# Altium schematic sheet [03]-CONNECTOR,SBC.SchDoc (continued)

## Library data of the parts
C1 = C0402S471K3RACAUTO: Cap Ceramic 470pF 25V X7R 10% SMD 0402 125°C Paper T/R; Manufacturer: KEMET; Component Kind: Standard; ComponentLink1Description: http://datasheet.octopart.com/C0402S471K3RACAUTO-KEMET-datasheet-84169754.pdf; ComponentLink1URL: http://datasheet.octopart.com/C0402S471K3RACAUTO-KEMET-datasheet-84169754.pdf; Manufacturer Part Number: C0402S471K3RACAUTO; Pricing 1: 1 = 0.53000, 10 = 0.37200, 100 = 0.24480, 500 = 0.18132, 1000 = 0.15412, 2500 = 0.14506, 5000 = 0.13599 (USD); Stock 1: 10000; Supplier 1: Digikey; Supplier Part Number 1: 399-19394-1-ND
C2 C3 C12 C23 = GRT21BR61E226ME13L: 0805 22 uF 25 V ±20% Tolerance X5R Multilayer Ceramic Capacitor; Manufacturer: Murata; Voltage Rating: 25V; Case Code (Imperial): 0805; Case Code (Metric): 2012; Component Kind: Standard; ComponentLink1Description: http://datasheet.octopart.com/GRT21BR61E226ME13L-Murata-datasheet-82944879.pdf?src-supplier=Future+Electronics; ComponentLink1URL: http://datasheet.octopart.com/GRT21BR61E226ME13L-Murata-datasheet-82944879.pdf?src-supplier=Future+Electronics; Length: 0.079inch; Manufacturer Part Number: GRT21BR61E226ME13L; Max Operating Temperature: 85°C; Min Operating Temperature: -55°C; Mount: Surface Mount; Packaging: Tape and Reel; Pricing 1: 1 = 0.73000, 10 = 0.51300, 100 = 0.33770, 500 = 0.25018, 1000 = 0.21265 (USD); Ratings: AEC-Q200; Stock 1: 494235; Supplier 1: Digi-Key; Supplier Part Number 1: 490-12389-1-ND; Thickness: 0.057inch; Tolerance: 20%; Width: 0.049inch
C4 = EMZA350ADA470MF61G: Aluminum Electrolytic Capacitor 47UF 35V 20%, SMD, Full Reel; Manufacturer: United Chemi-Con; Dielectric Material: Aluminium; ESR (Equivalent Series Resistance): 360mR; Lead Diameter: 0.8mm; Leakage Current: 3uA; Life (Hours): 2000Hour; Ripple Current: 240mA; Case/Package: Radial; Component Kind: Standard; ComponentLink1Description: http://www.farnell.com/datasheets/1470278.pdf?src-supplier=Element14; ComponentLink1URL: http://www.farnell.com/datasheets/1470278.pdf?src-supplier=Element14; ComponentLink2Description: http://datasheet.octopart.com/EMZA350ADA470MF61G-United-Chemi-Con-datasheet-10742166.pdf?src-supplier=Element14; ComponentLink2URL: http://datasheet.octopart.com/EMZA350ADA470MF61G-United-Chemi-Con-datasheet-10742166.pdf?src-supplier=Element14; ComponentLink3Description: http://datasheet.octopart.com/EMZA350ADA470MF61G-United-Chemi-Con-datasheet-23700410.pdf?src-supplier=Newark; ComponentLink3URL: http://datasheet.octopart.com/EMZA350ADA470MF61G-United-Chemi-Con-datasheet-23700410.pdf?src-supplier=Newark; ComponentLink4Description: http://datasheet.octopart.com/EMZA350ADA470MF61G-United-Chemi-Con-datasheet-14460521.pdf?src-supplier=Electro+Sonic; ComponentLink4URL: http://datasheet.octopart.com/EMZA350ADA470MF61G-United-Chemi-Con-datasheet-14460521.pdf?src-supplier=Electro+Sonic; ComponentLink5Description: http://datasheet.octopart.com/EMZA350ADA470MF61G-United-Chemi-Con-datasheet-15930.pdf?src-supplier=Digi-Key; ComponentLink5URL: http://datasheet.octopart.com/EMZA350ADA470MF61G-United-Chemi-Con-datasheet-15930.pdf?src-supplier=Digi-Key; ComponentLink6Description: http://datasheet.octopart.com/EMZA350ADA470MF61G-United-Chemi-Con-datasheet-8459204.pdf?src-supplier=Element14; ComponentLink6URL: http://datasheet.octopart.com/EMZA350ADA470MF61G-United-Chemi-Con-datasheet-8459204.pdf?src-supplier=Element14; ComponentLink7Description: http://datasheet.octopart.com/EMZA350ADA470MF61G-United-Chemi-Con-datasheet-8814951.pdf?src-supplier=Element14; ComponentLink7URL: http://datasheet.octopart.com/EMZA350ADA470MF61G-United-Chemi-Con-datasheet-8814951.pdf?src-supplier=Element14; ComponentLink8Description: http://datasheet.octopart.com/EMZA350ADA470MF61G-United-Chemi-Con-datasheet-21267361.pdf?src-supplier=Newark; ComponentLink8URL: http://datasheet.octopart.com/EMZA350ADA470MF61G-United-Chemi-Con-datasheet-21267361.pdf?src-supplier=Newark; ComponentLink9Description: http://datasheet.octopart.com/EMZA350ADA470MF61G-United-Chemi-Con-datasheet-33025258.pdf?src-supplier=Electro+Sonic; ComponentLink9URL: http://datasheet.octopart.com/EMZA350ADA470MF61G-United-Chemi-Con-datasheet-33025258.pdf?src-supplier=Electro+Sonic; ComponentLink10Description: http://datasheet.octopart.com/EMZA350ADA470MF61G-United-Chemi-Con-datasheet-43457141.pdf?src-supplier=Future+Electronics; ComponentLink10URL: http://datasheet.octopart.com/EMZA350ADA470MF61G-United-Chemi-Con-datasheet-43457141.pdf?src-supplier=Future+Electronics; ComponentLink11Description: http://datasheet.octopart.com/EMZA350ADA470MF61G-United-Chemi-Con-datasheet-62043274.pdf?src-supplier=Electro+Sonic; ComponentLink11URL: http://datasheet.octopart.com/EMZA350ADA470MF61G-United-Chemi-Con-datasheet-62043274.pdf?src-supplier=Electro+Sonic; Diameter: 6.3mm; Height: 5.8mm; Height - Seated (Max): 0.228inch; Impedance: 360mR; Lead Free: Lead Free; Lead Pitch: 1.9mm; Length: 5.8mm; Manufacturer Part Number: EMZA350ADA470MF61G; Max Operating Temperature: 105°C; Min Operating Temperature: -55°C; Mount: Surface Mount; Number of Pins: 61; Package Quantity: 1; Packaging: Tape and Reel; Pricing 1: 1 = 0.70000, 10 = 0.49500, 100 = 0.32600, 500 = 0.24150 (USD); REACH SVHC: Unknown; Ripple Current (AC): 240mA; Stock 1: 43543; Supplier 1: Digi-Key; Supplier Part Number 1: 565-2558-1-ND; Termination: SMD/SMT; Tolerance: 20%; Voltage Rating: 35V; Voltage Rating (DC): 35V
C5 = CGA6P3X7S1H106K250AE: Cap Ceramic 10uF 50V X7S 10% Pad SMD 1210 Soft Termination 125C Automotive T/R; Manufacturer: TDK; Case Code (Imperial): 1210; Case Code (Metric): 3225; Component Kind: Standard; ComponentLink1Description: http://www.mouser.com/ds/2/400/lcc_commercial_soft_en-775842.pdf?src-supplier=Mouser; ComponentLink1URL: http://www.mouser.com/ds/2/400/lcc_commercial_soft_en-775842.pdf?src-supplier=Mouser; ComponentLink2Description: http://www.farnell.com/datasheets/1863333.pdf?src-supplier=Element14; ComponentLink2URL: http://www.farnell.com/datasheets/1863333.pdf?src-supplier=Element14; ComponentLink3Description: http://datasheet.octopart.com/CGA6P3X7S1H106K250AE-TDK-datasheet-26765558.pdf?src-supplier=Newark; ComponentLink3URL: http://datasheet.octopart.com/CGA6P3X7S1H106K250AE-TDK-datasheet-26765558.pdf?src-supplier=Newark; ComponentLink4Description: http://datasheet.octopart.com/CGA6P3X7S1H106K250AE-TDK-datasheet-33037363.pdf?src-supplier=Newark; ComponentLink4URL: http://datasheet.octopart.com/CGA6P3X7S1H106K250AE-TDK-datasheet-33037363.pdf?src-supplier=Newark; ComponentLink5Description: http://datasheet.octopart.com/CGA6P3X7S1H106K250AE-TDK-datasheet-82475251.pdf?src-supplier=Newark; ComponentLink5URL: http://datasheet.octopart.com/CGA6P3X7S1H106K250AE-TDK-datasheet-82475251.pdf?src-supplier=Newark; ComponentLink6Description: http://datasheet.octopart.com/CGA6P3X7S1H106K250AE-TDK-datasheet-57863114.pdf?src-supplier=Newark; ComponentLink6URL: http://datasheet.octopart.com/CGA6P3X7S1H106K250AE-TDK-datasheet-57863114.pdf?src-supplier=Newark; ComponentLink7Description: http://datasheet.octopart.com/CGA6P3X7S1H106K250AE-TDK-datasheet-41194876.pdf?src-supplier=Future+Electronics; ComponentLink7URL: http://datasheet.octopart.com/CGA6P3X7S1H106K250AE-TDK-datasheet-41194876.pdf?src-supplier=Future+Electronics; ComponentLink8Description: http://datasheet.octopart.com/CGA6P3X7S1H106K250AE.-TDK-datasheet-33009218.pdf?src-supplier=Newark; ComponentLink8URL: http://datasheet.octopart.com/CGA6P3X7S1H106K250AE.-TDK-datasheet-33009218.pdf?src-supplier=Newark; Depth: 2.5mm; Dielectric: X7S; Features: Soft Termination; Height: 2.5mm; Lead Free: Lead Free; Length: 3.2mm; Manufacturer Part Number: CGA6P3X7S1H106K250AE; Max Operating Temperature: 125°C; Min Operating Temperature: -55°C; Mount: Surface Mount; Package Quantity: 1000; Packaging: Tape and Reel; Pricing 1: 1 = 1.84000, 10 = 1.44900, 100 = 1.08680, 500 = 0.82110 (USD); Ratings: AEC-Q200; REACH SVHC: No SVHC; Stock 1: 58465; Supplier 1: Digi-Key; Supplier Part Number 1: 445-8792-1-ND; Termination: SMD/SMT; Thickness: 0.11inch; Tolerance: 10%; Voltage Rating: 50V; Voltage Rating (DC): 50V; Weight: 0.000564oz; Width: 2.5mm
C6 C7 = CGA5L3X7R1H475K160AE: Cap Ceramic 4.7uF 50V X7R 10% Pad SMD 1206 Soft Termination 125C Automotive T/R; Manufacturer: TDK; Case Code (Imperial): 1206; Case Code (Metric): 3216; Component Kind: Standard; ComponentLink1Description: http://datasheet.octopart.com/CGA5L3X7R1H475K160AE-TDK-datasheet-57863114.pdf?src-supplier=Newark; ComponentLink1URL: http://datasheet.octopart.com/CGA5L3X7R1H475K160AE-TDK-datasheet-57863114.pdf?src-supplier=Newark; ComponentLink2Description: http://datasheet.octopart.com/CGA5L3X7R1H475K160AE-TDK-datasheet-82475251.pdf?src-supplier=Newark; ComponentLink2URL: http://datasheet.octopart.com/CGA5L3X7R1H475K160AE-TDK-datasheet-82475251.pdf?src-supplier=Newark; ComponentLink3Description: http://datasheet.octopart.com/CGA5L3X7R1H475K160AE-TDK-datasheet-24967704.pdf?src-supplier=Future+Electronics; ComponentLink3URL: http://datasheet.octopart.com/CGA5L3X7R1H475K160AE-TDK-datasheet-24967704.pdf?src-supplier=Future+Electronics; ComponentLink4Description: http://datasheet.octopart.com/CGA5L3X7R1H475K160AE-TDK-datasheet-31895768.pdf?src-supplier=Future+Electronics; ComponentLink4URL: http://datasheet.octopart.com/CGA5L3X7R1H475K160AE-TDK-datasheet-31895768.pdf?src-supplier=Future+Electronics; Dielectric: X7R; Features: Soft Termination; Height: 1.6mm; Lead Free: Lead Free; Length: 0.126inch; Manufacturer Part Number: CGA5L3X7R1H475K160AE; Max Operating Temperature: 125°C; Min Operating Temperature: -55°C; Mount: Surface Mount; Package Quantity: 2000; Packaging: Tape and Reel; Pricing 1: 1 = 0.98000, 10 = 0.72500, 100 = 0.49680, 500 = 0.39330, 1000 = 0.33120 (USD); Ratings: AEC-Q200; REACH SVHC: No SVHC; Stock 1: 49519; Supplier 1: Digi-Key; Supplier Part Number 1: 445-172481-1-ND; Termination: SMD/SMT; Thickness: 0.075inch; Tolerance: 10%; Voltage Rating: 50V; Voltage Rating (DC): 50V; Weight: 0.000571oz; Width: 0.063inch
C8 C15 C22 C24 = 06035C104K4Z2A: Capacitor: ceramic; MLCC; 100nF; 50V; X7R; ±10%; SMD; 0603; Manufacturer: AVX; Dissipation Factor: 2.5%; Case Code (Imperial): 0603; Case Code (Metric): 1608; Component Kind: Standard; ComponentLink1Description: https://www.avx.com/docs/Catalogs/flexiterm.pdf?src-supplier=Avnet+Europe; ComponentLink1URL: https://www.avx.com/docs/Catalogs/flexiterm.pdf?src-supplier=Avnet+Europe; ComponentLink2Description: http://catalogs.avx.com/SurfaceMount.pdf?src-supplier=Digi-Key; ComponentLink2URL: http://catalogs.avx.com/SurfaceMount.pdf?src-supplier=Digi-Key; ComponentLink3Description: https://www.verical.com/server-webapp/html/getDatasheet?partID=494917&src-supplier=Verical; ComponentLink3URL: https://www.verical.com/server-webapp/html/getDatasheet?partID=494917&src-supplier=Verical; ComponentLink4Description: http://www.farnell.com/datasheets/1679506.pdf?src-supplier=Element14; ComponentLink4URL: http://www.farnell.com/datasheets/1679506.pdf?src-supplier=Element14; ComponentLink5Description: http://datasheet.octopart.com/06035C104K4Z2A-AVX-datasheet-12509786.pdf?src-supplier=Newark; ComponentLink5URL: http://datasheet.octopart.com/06035C104K4Z2A-AVX-datasheet-12509786.pdf?src-supplier=Newark; ComponentLink6Description: http://datasheet.octopart.com/06035C104K4Z2A-AVX-datasheet-12587061.pdf?src-supplier=Newark; ComponentLink6URL: http://datasheet.octopart.com/06035C104K4Z2A-AVX-datasheet-12587061.pdf?src-supplier=Newark; ComponentLink7Description: http://datasheet.octopart.com/06035C104K4Z2A-AVX-datasheet-78690206.pdf?src-supplier=Newark; ComponentLink7URL: http://datasheet.octopart.com/06035C104K4Z2A-AVX-datasheet-78690206.pdf?src-supplier=Newark; ComponentLink8Description: http://datasheet.octopart.com/06035C104K4Z2A-AVX-datasheet-8446237.pdf?src-supplier=TME; ComponentLink8URL: http://datasheet.octopart.com/06035C104K4Z2A-AVX-datasheet-8446237.pdf?src-supplier=TME; ComponentLink9Description: http://datasheet.octopart.com/06035C104K4Z2A-AVX-datasheet-8451785.pdf?src-supplier=TME; ComponentLink9URL: http://datasheet.octopart.com/06035C104K4Z2A-AVX-datasheet-8451785.pdf?src-supplier=TME; ComponentLink10Description: http://datasheet.octopart.com/06035C104K4Z2A-AVX-datasheet-50865432.pdf?src-supplier=TME; ComponentLink10URL: http://datasheet.octopart.com/06035C104K4Z2A-AVX-datasheet-50865432.pdf?src-supplier=TME; ComponentLink11Description: http://datasheet.octopart.com/06035C104K4Z2A-AVX-datasheet-167033.pdf?src-supplier=Farnell; ComponentLink11URL: http://datasheet.octopart.com/06035C104K4Z2A-AVX-datasheet-167033.pdf?src-supplier=Farnell; ComponentLink12Description: http://datasheet.octopart.com/06035C104K4Z2A-AVX-datasheet-5313617.pdf?src-supplier=Farnell; ComponentLink12URL: http://datasheet.octopart.com/06035C104K4Z2A-AVX-datasheet-5313617.pdf?src-supplier=Farnell; ComponentLink13Description: http://datasheet.octopart.com/06035C104K4Z2A-AVX-datasheet-8113618.pdf?src-supplier=Farnell; ComponentLink13URL: http://datasheet.octopart.com/06035C104K4Z2A-AVX-datasheet-8113618.pdf?src-supplier=Farnell; ComponentLink14Description: http://datasheet.octopart.com/06035C104K4Z2A-AVX-Interconnect---Elco-datasheet-8458193.pdf?src-supplier=Factory+Futures; ComponentLink14URL: http://datasheet.octopart.com/06035C104K4Z2A-AVX-Interconnect---Elco-datasheet-8458193.pdf?src-supplier=Factory+Futures; ComponentLink15Description: http://datasheet.octopart.com/06035C104K4Z2A-AVX-datasheet-26446220.pdf?src-supplier=Newark; ComponentLink15URL: http://datasheet.octopart.com/06035C104K4Z2A-AVX-datasheet-26446220.pdf?src-supplier=Newark; ComponentLink16Description: http://datasheet.octopart.com/06035C104K4Z2A-AVX-datasheet-540940.pdf?src-supplier=Future+Electronics; ComponentLink16URL: http://datasheet.octopart.com/06035C104K4Z2A-AVX-datasheet-540940.pdf?src-supplier=Future+Electronics; ComponentLink17Description: http://datasheet.octopart.com/06035C104K4Z2A-AVX-datasheet-5313949.pdf?src-supplier=Future+Electronics; ComponentLink17URL: http://datasheet.octopart.com/06035C104K4Z2A-AVX-datasheet-5313949.pdf?src-supplier=Future+Electronics; ComponentLink18Description: http://datasheet.octopart.com/06035C104K4Z2A-AVX-datasheet-65931687.pdf?src-supplier=Element14; ComponentLink18URL: http://datasheet.octopart.com/06035C104K4Z2A-AVX-datasheet-65931687.pdf?src-supplier=Element14; ComponentLink19Description: http://datasheet.octopart.com/06035C104K4Z2A-AVX-datasheet-13516023.pdf; ComponentLink19URL: http://datasheet.octopart.com/06035C104K4Z2A-AVX-datasheet-13516023.pdf; Contact Plating: Matte,Tin; Depth: 0.81mm; Dielectric: X7R; Features: Soft Termination; Height: 0.035mm; Lead Free: Lead Free; Length: 1.6mm; Library Name: WeOnReferenceBoard.SCHLIB; Manufacturer Part Number: 06035C104K4Z2A; Max Operating Temperature: 125°C; Min Operating Temperature: -55°C; Mount: Surface Mount; Number of Pins: 2; Package Quantity: 1; Packaging: Tape and Reel; Pricing 1: 1 = 0.20000, 10 = 0.13600, 100 = 0.06870, 500 = 0.04702, 1000 = 0.04159 (USD); Radiation Hardening: No; Ratings: AEC-Q200; REACH SVHC: No SVHC; Stock 1: 777100; Supplier 1: Digi-Key; Supplier Part Number 1: 478-6344-1-ND; Termination: SMD/SMT; Thickness: 0.037inch; Tolerance: 10%; Voltage Rating: 50V; Voltage Rating (DC): 50V; Width: 0.81mm
C9 C13 C14 C18 C19 = GCM155R71C104KA55D: Multilayer Ceramic Capacitors (MLCC) - SMD/SMT 0402 0.1uF 16volts X7R 10%; Manufacturer: Murata; Voltage: 16V; Case/Package: 0402; Case Code (Imperial): 0402; Case Code (Metric): 1005; Component Kind: Standard; ComponentLink1Description: http://psearch.en.murata.com/capacitor/product/GCM155R71C104KA55%23.pdf?src-supplier=Avnet; ComponentLink1URL: http://psearch.en.murata.com/capacitor/product/GCM155R71C104KA55%23.pdf?src-supplier=Avnet; ComponentLink2Description: http://search.murata.co.jp/Ceramy/image/img/A01X/partnumbering_e_02.pdf?src-supplier=Digi-Key; ComponentLink2URL: http://search.murata.co.jp/Ceramy/image/img/A01X/partnumbering_e_02.pdf?src-supplier=Digi-Key; ComponentLink3Description: http://uk.rs-online.com/webdocs/1423/0900766b81423e06.pdf?src-supplier=RSComponents; ComponentLink3URL: http://uk.rs-online.com/webdocs/1423/0900766b81423e06.pdf?src-supplier=RSComponents; ComponentLink4Description: https://www.verical.com/server-webapp/html/getDatasheet?partID=220774&src-supplier=Verical; ComponentLink4URL: https://www.verical.com/server-webapp/html/getDatasheet?partID=220774&src-supplier=Verical; ComponentLink5Description: http://datasheet.octopart.com/GCM155R71C104KA55D-Murata-datasheet-11777063.pdf?src-supplier=_legacy+Avnet; ComponentLink5URL: http://datasheet.octopart.com/GCM155R71C104KA55D-Murata-datasheet-11777063.pdf?src-supplier=_legacy+Avnet; ComponentLink6Description: http://datasheet.octopart.com/GCM155R71C104KA55D-Murata-datasheet-14404342.pdf?src-supplier=Future+Electronics; ComponentLink6URL: http://datasheet.octopart.com/GCM155R71C104KA55D-Murata-datasheet-14404342.pdf?src-supplier=Future+Electronics; ComponentLink7Description: http://datasheet.octopart.com/GCM155R71C104KA55D-Murata-datasheet-12515163.pdf?src-supplier=Farnell; ComponentLink7URL: http://datasheet.octopart.com/GCM155R71C104KA55D-Murata-datasheet-12515163.pdf?src-supplier=Farnell; ComponentLink8Description: http://datasheet.octopart.com/GCM155R71C104KA55D-Murata-datasheet-44104237.pdf?src-supplier=Future+Electronics; ComponentLink8URL: http://datasheet.octopart.com/GCM155R71C104KA55D-Murata-datasheet-44104237.pdf?src-supplier=Future+Electronics; ComponentLink9Description: http://datasheet.octopart.com/GCM155R71C104KA55D-Murata-datasheet-62117434.pdf?src-supplier=TME; ComponentLink9URL: http://datasheet.octopart.com/GCM155R71C104KA55D-Murata-datasheet-62117434.pdf?src-supplier=TME; Depth: 0.5mm; Dielectric: X7R; Height: 0.5mm; Lead Free: Lead Free; Length: 1mm; Manufacturer Part Number: GCM155R71C104KA55D; Max Operating Temperature: 125°C; Min Operating Temperature: -55°C; Mount: Surface Mount; Package Quantity: 1; Packaging: Tape and Reel; Pricing 1: 10000 = 0.01910, 1 = 0.05560, 10 = 0.04750, 25 = 0.03370, 100 = 0.02190, 250 = 0.02080 (USD); Radiation Hardening: No; Ratings: AEC-Q200; REACH SVHC: No SVHC; Stock 1: 10660000; Supplier 1: Arrow; Supplier Part Number 1: GCM155R71C104KA55D; Termination: SMD/SMT; Thickness: 0.022inch; Tolerance: 10%; Voltage Rating: 16V; Voltage Rating (DC): 16V; Width: 0.02inch
C10 C20 = C1206X104K2RACAUTO: Cap Ceramic 0.1uF 200V X7R 10% Pad SMD 1206 Flexible Termination 125C Automotive Medical T/R; Manufacturer: KEMET; Case Code (Imperial): 1206; Case Code (Metric): 3216; Component Kind: Standard; ComponentLink1Description: http://datasheet.octopart.com/C1206X104K2RACAUTO-KEMET-datasheet-101607918.pdf?src-supplier=Farnell; ComponentLink1URL: http://datasheet.octopart.com/C1206X104K2RACAUTO-KEMET-datasheet-101607918.pdf?src-supplier=Farnell; ComponentLink2Description: http://datasheet.octopart.com/C1206X104K2RACAUTO-KEMET-datasheet-84169754.pdf; ComponentLink2URL: http://datasheet.octopart.com/C1206X104K2RACAUTO-KEMET-datasheet-84169754.pdf; Depth: 1.6mm; Dielectric: X7R; Dielectric Material: Ceramic; Features: Soft Termination; Length: 0.13inch; Library Name: WeOnReferenceSchlib.SchLib; Manufacturer Part Number: C1206X104K2RACAUTO; Max Operating Temperature: 125°C; Min Operating Temperature: -55°C; Mount: Surface Mount; Packaging: Tape and Reel; Pricing 1: 1 = 0.82000, 10 = 0.60800, 100 = 0.41660, 500 = 0.32984, 1000 = 0.27777 (USD); Ratings: AEC-Q200; Stock 1: 17486; Supplier 1: Digi-Key; Supplier Part Number 1: 399-12986-1-ND; Termination: SMD/SMT; Thickness: 0.055inch; Tolerance: 10%; Voltage Rating: 200V; Voltage Rating (DC): 200V; Width: 0.063inch
C11 = UMK105CH181JVHF: Cap Ceramic 180pF 50V 0402; Manufacturer: Taiyo Yuden; Component Kind: Standard; Manufacturer Part Number: UMK105CH181JVHF; Pricing 1: 1 = 0.10000, 10 = 0.05600, 100 = 0.02500, 500 = 0.01790, 1000 = 0.01406, 2500 = 0.01278, 5000 = 0.01176 (USD); Stock 1: 1875; Supplier 1: Digikey; Supplier Part Number 1: 587-5073-1-ND
C16 = C0402C681K5RACAUTO: Cap Ceramic 680pF 50V X7R 10% SMD 0402 125°C Punched Paper T/R; Manufacturer: KEMET; Case/Package: 0402; Component Kind: Standard; ComponentLink1Description: http://datasheet.octopart.com/C0402C681K5RACAUTO-KEMET-datasheet-84169754.pdf; ComponentLink1URL: http://datasheet.octopart.com/C0402C681K5RACAUTO-KEMET-datasheet-84169754.pdf; Dielectric: X7R; Dielectric Material: Ceramic; Manufacturer Part Number: C0402C681K5RACAUTO; Pricing 1: 1 = 0.12000, 10 = 0.08400, 100 = 0.03960, 500 = 0.02778, 1000 = 0.02300, 2500 = 0.02140, 5000 = 0.01958 (USD); Stock 1: 85945; Supplier 1: Digi-Key; Tolerance: 10%; Voltage Rating (DC): 50V
C17 = GCM1555C1H151JA16D: 0402 150 pF 50 V ±5 % Tolerance C0G SMT Multilayer Ceramic Capacitor; Manufacturer: Murata; Tolerance: 5%; Voltage: 50V; Case/Package: 0402; Case Code (Imperial): 0402; Case Code (Metric): 1005; Component Kind: Standard; ComponentLink1Description: http://search.murata.co.jp/Ceramy/image/img/A01X/partnumbering_e_02.pdf?src-supplier=Digi-Key; ComponentLink1URL: http://search.murata.co.jp/Ceramy/image/img/A01X/partnumbering_e_02.pdf?src-supplier=Digi-Key; ComponentLink2Description: http://datasheet.octopart.com/GCM1555C1H151JA16D-Murata-datasheet-11974918.pdf?src-supplier=Arrow; ComponentLink2URL: http://datasheet.octopart.com/GCM1555C1H151JA16D-Murata-datasheet-11974918.pdf?src-supplier=Arrow; ComponentLink3Description: http://datasheet.octopart.com/GCM1555C1H151JA16D-Murata-datasheet-14404323.pdf; ComponentLink3URL: http://datasheet.octopart.com/GCM1555C1H151JA16D-Murata-datasheet-14404323.pdf; ComponentLink4Description: http://datasheet.octopart.com/GCM1555C1H151JA16D-Murata-datasheet-42223911.pdf?src-supplier=Future+Electronics; ComponentLink4URL: http://datasheet.octopart.com/GCM1555C1H151JA16D-Murata-datasheet-42223911.pdf?src-supplier=Future+Electronics; Depth: 0.5mm; Dielectric: C0G; Height: 0.5mm; Lead Free: Lead Free; Length: 1mm; Library Name: WeOnReferenceBoard.SCHLIB; Manufacturer Part Number: GCM1555C1H151JA16D; Max Operating Temperature: 125°C; Min Operating Temperature: -55°C; Mount: Surface Mount; Package Quantity: 1; Packaging: Tape and Reel; Pricing 1: 1 = 0.01650 (USD); Radiation Hardening: No; Ratings: AEC-Q200; Stock 1: 10000; Supplier 1: Arrow; Termination: SMD/SMT; Thickness: 0.022inch; Voltage Rating: 50V; Voltage Rating (DC): 50V; Width: 0.02inch
C21 = CGA3E1X7R1C105K080AC: CGA Series 0603 1 uF 16 V ±10 % Tolerance X7R SMT Multilayer Ceramic Capacitor; Manufacturer: TDK; Tolerance: 10%; Voltage Rating: 16V; Case Code (Imperial): 0603; Case Code (Metric): 1608; Component Kind: Standard; ComponentLink1Description: http://datasheet.octopart.com/CGA3E1X7R1C105K080AC-TDK-datasheet-43366117.pdf?src-supplier=Newark; ComponentLink1URL: http://datasheet.octopart.com/CGA3E1X7R1C105K080AC-TDK-datasheet-43366117.pdf?src-supplier=Newark; ComponentLink2Description: http://datasheet.octopart.com/CGA3E1X7R1C105K080AC-TDK-datasheet-82475251.pdf?src-supplier=Newark; ComponentLink2URL: http://datasheet.octopart.com/CGA3E1X7R1C105K080AC-TDK-datasheet-82475251.pdf?src-supplier=Newark; Dielectric: X7R; Height: 0.8mm; Lead Free: Lead Free; Length: 0.063inch; Manufacturer Part Number: CGA3E1X7R1C105K080AC; Max Operating Temperature: 125°C; Min Operating Temperature: -55°C; Mount: Surface Mount; Package Quantity: 4000; Packaging: Tape and Reel; Pricing 1: 1 = 0.15000, 10 = 0.10900, 100 = 0.05110, 500 = 0.03580, 1000 = 0.02963 (USD); Ratings: AEC-Q200; REACH SVHC: No SVHC; Stock 1: 369291; Supplier 1: Digi-Key; Termination: SMD/SMT; Thickness: 0.035inch; Voltage Rating (DC): 16V; Weight: 7.1E-05oz; Width: 0.8mm
D1 D2 D4 = SSB43LHE3_A/I: Diode Schottky Rectifier 30V 4A 2-Pin DO-214AA Plastic T/R; Manufacturer: Vishay; Component Kind: Standard; Manufacturer Part Number: SSB43LHE3_A/I; Max Reverse Voltage (DC): 30V; Mount: Surface Mount; Packaging: Tape and Reel; Pricing 1: 10000 = 0.17300 (USD); Stock 1: 2659; Supplier 1: Mouser; Supplier Part Number 1: 78-SSB43LHE3_A/I
D3 = PMEG045V050EPDAZ: Diode Schottky Rectifier 45V 5A 3-Pin SOT-1289 T/R; Manufacturer: Nexperia; Component Kind: Standard; ComponentLink1Description: http://datasheet.octopart.com/PMEG045V050EPDAZ-Nexperia-datasheet-87844653.pdf?src-supplier=Nexperia; ComponentLink1URL: http://datasheet.octopart.com/PMEG045V050EPDAZ-Nexperia-datasheet-87844653.pdf?src-supplier=Nexperia; ComponentLink2Description: http://datasheet.octopart.com/PMEG045V050EPDAZ-NXP-Semiconductors-datasheet-27240176.pdf?src-supplier=NXP+Semiconductors; ComponentLink2URL: http://datasheet.octopart.com/PMEG045V050EPDAZ-NXP-Semiconductors-datasheet-27240176.pdf?src-supplier=NXP+Semiconductors; Manufacturer Part Number: PMEG045V050EPDAZ; Pricing 1: 1 = 0.69000, 10 = 0.60700, 100 = 0.46520, 500 = 0.36772, 1000 = 0.29417 (USD); Stock 1: 5000; Supplier 1: Digikey; Supplier Part Number 1: 1727-7634-1-ND
D5 = NSVR0240P2T5G: Diode Schottky Barrier Diode 40V 0.2A 2-Pin SOD-923 T/R; Manufacturer: ON Semiconductor; Average Rectified Current: 200mA; Component Kind: Standard; ComponentLink1Description: http://datasheet.octopart.com/NSVR0240P2T5G-ON-Semiconductor-datasheet-13705431.pdf?src-supplier=ON+Semiconductor; ComponentLink1URL: http://datasheet.octopart.com/NSVR0240P2T5G-ON-Semiconductor-datasheet-13705431.pdf?src-supplier=ON+Semiconductor; Lead Free: Lead Free; Manufacturer Part Number: NSVR0240P2T5G; Max Reverse Voltage (DC): 40V; Mount: Surface Mount; Packaging: Tape and Reel; Pricing 1: 1 = 0.42000, 10 = 0.31700, 50 = 0.31700, 100 = 0.17200, 1000 = 0.12900, 10000 = 0.10400 (USD); Stock 1: 7618; Supplier 1: Mouser; Supplier Part Number 1: 863-NSVR0240P2T5G
DZ1 DZ2 = SM6T36CAHE3/5B: Diode TVS Single Bi-Dir 30.8V 600W Automotive 2-Pin SMB T/R; Manufacturer: Vishay; Clamping Voltage: 64.3V; Direction: Bidirectional; ESD Protection: No; Leakage Current: 1uA; Max Surge Current: 62A; Number of Bidirectional Channels: 1; Test Current: 1mA; Case/Package: SMB; Component Kind: Standard; Composition: Zener; Contact Plating: Matte,Tin; Element Configuration: Single; Library Name: WeOnReferenceSchlib.SchLib; Manufacturer Part Number: SM6T36CAHE3/5B; Max Operating Temperature: 150°C; Max Reverse Leakage Current: 1uA; Min Breakdown Voltage: 34.2V; Min Operating Temperature: -65°C; Mount: Surface Mount; Number of Elements: 1; Number of Pins: 2; Operating Supply Voltage: 30.8V; Package Quantity: 3200; Packaging: Tape and Reel; Peak Pulse Current: 62A; Peak Pulse Power: 600W; Polarity: Bidirectional; Power Line Protection: No; Pricing 1: 1 = 0.55000, 10 = 0.43500, 25 = 0.42950, 100 = 0.33080, 250 = 0.32720, 500 = 0.28520, 1000 = 0.22660, 3000 = 0.22650 (USD); Radiation Hardening: No; Reverse Breakdown Voltage: 34.2V; Reverse Standoff Voltage: 30.8V; Stock 1: 3200; Supplier 1: Arrow; Supplier Part Number 1: SM6T36CAHE3/5B
DZ3 DZ4 = SZMMBZ5242BL**G: Manufacturer: ON Semiconductor; Manufacturer Part Number: SZMMBZ5242BLT1G; Element Configuration: Single; GateName_1: G$1; Impedance: 30R; Max Power Dissipation: 225mW; Max Reverse Leakage Current: 1uA; SymbolName_1: ZENNER; Tolerance: 5%; Case/Package: SOT-23-3; Component Kind: Standard; ComponentLink1Description: http://www.onsemi.com/pub_link/Collateral/MMBZ5221BLT1-D.PDF?src-supplier=Digi-Key; ComponentLink1URL: http://www.onsemi.com/pub_link/Collateral/MMBZ5221BLT1-D.PDF?src-supplier=Digi-Key; ComponentLink2Description: http://datasheet.octopart.com/SZMMBZ5242BLT1G-ON-Semiconductor-datasheet-10741849.pdf?src-supplier=Future+Electronics; ComponentLink2URL: http://datasheet.octopart.com/SZMMBZ5242BLT1G-ON-Semiconductor-datasheet-10741849.pdf?src-supplier=Future+Electronics; ComponentLink3Description: http://datasheet.octopart.com/SZMMBZ5242BLT1G-ON-Semiconductor-datasheet-109277.pdf?src-supplier=ON+Semiconductor; ComponentLink3URL: http://datasheet.octopart.com/SZMMBZ5242BLT1G-ON-Semiconductor-datasheet-109277.pdf?src-supplier=ON+Semiconductor; ComponentLink4Description: http://datasheet.octopart.com/SZMMBZ5242BLT1G-ON-Semiconductor-datasheet-78758894.pdf?src-supplier=Newark; ComponentLink4URL: http://datasheet.octopart.com/SZMMBZ5242BLT1G-ON-Semiconductor-datasheet-78758894.pdf?src-supplier=Newark; DeviceSetName: SZMMBZ5242BL**G; Lead Free: Lead Free; Library Name: WeOnReferenceBoard.SCHLIB; LibraryName: MINI50; Max Operating Temperature: 150°C; Min Operating Temperature: -65°C; Number of Pins: 3; Package Quantity: 3000; Packaging: Tape and Reel; Pricing 1: 1 = 0.16200, 25 = 0.15000, 50 = 0.11600, 100 = 0.08200, 250 = 0.06700, 500 = 0.05100, 1000 = 0.03500 (USD); Radiation Hardening: No; Stock 1: 2015; Supplier 1: Newark; Supplier Part Number 1: 13AC6258; Voltage Tolerance: 5%
L1 = XAL6060-223MEC: Power inductor, shielded, 20% tol, SMT, RoHS, halogen f; Manufacturer: Coilcraft; Core Material: Composite; DC Resistance (DCR): 60.63mR; Inductance: 22uh; Max DC Current: 5A; Saturation Current: 5.6A; Self Resonant Frequency: 9MHz; Test Frequency: 100kHz; Case/Package: SMD/SMT; Component Kind: Standard; ComponentLink1Description: http://www.mouser.com/ds/2/597/xal60xx-270658.pdf?src-supplier=Mouser; ComponentLink1URL: http://www.mouser.com/ds/2/597/xal60xx-270658.pdf?src-supplier=Mouser; ComponentLink2Description: http://www.farnell.com/datasheets/1681993.pdf?src-supplier=Element14; ComponentLink2URL: http://www.farnell.com/datasheets/1681993.pdf?src-supplier=Element14; ComponentLink3Description: http://datasheet.octopart.com/XAL6060-223MEC-Coilcraft-datasheet-10791337.pdf?src-supplier=Coilcraft+Direct; ComponentLink3URL: http://datasheet.octopart.com/XAL6060-223MEC-Coilcraft-datasheet-10791337.pdf?src-supplier=Coilcraft+Direct; ComponentLink4Description: http://datasheet.octopart.com/XAL6060-223MEC-Coilcraft-datasheet-14354382.pdf?src-supplier=Newark; ComponentLink4URL: http://datasheet.octopart.com/XAL6060-223MEC-Coilcraft-datasheet-14354382.pdf?src-supplier=Newark; Height: 6.1mm; Inductance Tolerance: 20%; Lead Free: Contains Lead; Length: 6.76mm; Library Name: WeOnReferenceBoard.SCHLIB; Manufacturer Part Number: XAL6060-223MEC; Max Operating Temperature: 125°C; Min Operating Temperature: -40°C; Mount: Surface Mount; Package Quantity: 250; Packaging: Tape and Reel; Pricing 1: 1 = 2.58000, 10 = 2.58000, 50 = 2.58000, 100 = 2.25000, 1000 = 1.18000, 10000 = 1.18000 (USD); REACH SVHC: No SVHC; RMS Current (Irms): 5A; Shielding: Shielded; Stock 1: 19462; Supplier 1: Mouser; Supplier Part Number 1: 994-XAL6060-223MEC; Termination: SMD/SMT; Tolerance: 20%; Width: 6.56mm
L2 = XAL4020-102MEB: Power inductor, shielded, 20% tol, SMT, RoHS, halogen f; Manufacturer: Coilcraft; Core Material: Composite; DC Resistance (DCR): 14.6mR; Max DC Current: 9.6A; Saturation Current: 8.7A; Self Resonant Frequency: 79MHz; Test Frequency: 100kHz; Case/Package: SMD/SMT; Component Kind: Standard; ComponentLink1Description: http://www.mouser.com/ds/2/597/xal4000-270751.pdf?src-supplier=Mouser; ComponentLink1URL: http://www.mouser.com/ds/2/597/xal4000-270751.pdf?src-supplier=Mouser; ComponentLink2Description: http://www.farnell.com/datasheets/1681987.pdf?src-supplier=Element14; ComponentLink2URL: http://www.farnell.com/datasheets/1681987.pdf?src-supplier=Element14; ComponentLink3Description: http://datasheet.octopart.com/XAL4020-102MEB-Coilcraft-datasheet-10791550.pdf?src-supplier=Coilcraft+Direct; ComponentLink3URL: http://datasheet.octopart.com/XAL4020-102MEB-Coilcraft-datasheet-10791550.pdf?src-supplier=Coilcraft+Direct; ComponentLink4Description: http://datasheet.octopart.com/XAL4020-102MEB-Coilcraft-datasheet-14354380.pdf?src-supplier=Newark; ComponentLink4URL: http://datasheet.octopart.com/XAL4020-102MEB-Coilcraft-datasheet-14354380.pdf?src-supplier=Newark; Height: 2.1mm; Inductance Tolerance: 20%; Lead Free: Contains Lead; Length: 4.3mm; Library Name: WeOnReferenceBoard.SCHLIB; Manufacturer Part Number: XAL4020-102MEB; Max Operating Temperature: 125°C; Min Operating Temperature: -40°C; Mount: Surface Mount; Package Quantity: 20; Packaging: Tape and Reel; Pricing 1: 1 = 1.80000, 10 = 1.50000, 50 = 1.46000, 100 = 1.44000, 1000 = 0.87000, 10000 = 0.87000 (USD); REACH SVHC: No SVHC; RMS Current (Irms): 9.6A; Shielding: Shielded; Stock 1: 5843; Supplier 1: Mouser; Supplier Part Number 1: 994-XAL4020-102MEB; Termination: SMD/SMT; Tolerance: 20%; Width: 4.3mm
L3 = XAL4020-222MEB: Power inductor, shielded, 20% tol, SMT, RoHS, halogen f; Manufacturer: Coilcraft; Core Material: Composite; DC Resistance (DCR): 38.7mR; Self Resonant Frequency: 52MHz; Test Frequency: 100kHz; Component Kind: Standard; ComponentLink1Description: http://www.mouser.com/ds/2/597/xal4000-270751.pdf?src-supplier=Mouser; ComponentLink1URL: http://www.mouser.com/ds/2/597/xal4000-270751.pdf?src-supplier=Mouser; ComponentLink2Description: http://datasheet.octopart.com/XAL4020-222MEB-Coilcraft-datasheet-10791550.pdf?src-supplier=Coilcraft+Direct; ComponentLink2URL: http://datasheet.octopart.com/XAL4020-222MEB-Coilcraft-datasheet-10791550.pdf?src-supplier=Coilcraft+Direct; Height: 2.1mm; Lead Free: Contains Lead; Length: 4.3mm; Manufacturer Part Number: XAL4020-222MEB; Max Operating Temperature: 125°C; Min Operating Temperature: -40°C; Packaging: Cut Tape; Pricing 1: 1 = 1.80000, 10 = 1.50000, 50 = 1.46000, 100 = 1.44000, 1000 = 0.87000, 10000 = 0.87000 (USD); Shielding: Shielded; Stock 1: 5295; Supplier 1: Mouser; Supplier Part Number 1: 994-XAL4020-222MEB; Termination: SMD/SMT; Tolerance: 20%; Width: 4.3mm
P1 P3 = 34826-0124: Mini50™ Unsealed, Dual Row, Right-Angle Header, 12 Circuit, Polarization Option A, Black, Tray; Manufacturer: Molex; Current Rating: 3A; Gender: Male; Glow Wire Compliant: Non-compliant; Number of Circuits: 12; Pitch: 2mm; Voltage Rating: 500V; Case/Package: 0124; Color: Black; Component Kind: Standard; ComponentLink1Description: http://www.farnell.com/datasheets/1780813.pdf?src-supplier=Element14; ComponentLink1URL: http://www.farnell.com/datasheets/1780813.pdf?src-supplier=Element14; ComponentLink2Description: http://datasheet.octopart.com/34826-0124-Molex-datasheet-20772911.pdf?src-supplier=Molex; ComponentLink2URL: http://datasheet.octopart.com/34826-0124-Molex-datasheet-20772911.pdf?src-supplier=Molex; ComponentLink3Description: http://sigma.octopart.com/20772913/technical_drawing/Molex-348260124.pdf?src-supplier=Molex; ComponentLink3URL: http://sigma.octopart.com/20772913/technical_drawing/Molex-348260124.pdf?src-supplier=Molex; ComponentLink4Description: http://datasheet.octopart.com/34826-0124-Molex-datasheet-21395142.pdf?src-supplier=Farnell; ComponentLink4URL: http://datasheet.octopart.com/34826-0124-Molex-datasheet-21395142.pdf?src-supplier=Farnell; ComponentLink5Description: http://datasheet.octopart.com/34826-0124-Molex-datasheet-77557790.pdf?src-supplier=Newark; ComponentLink5URL: http://datasheet.octopart.com/34826-0124-Molex-datasheet-77557790.pdf?src-supplier=Newark; ComponentLink6Description: http://datasheet.octopart.com/34826-0124-Molex-datasheet-37169430.pdf?src-supplier=Electro+Sonic; ComponentLink6URL: http://datasheet.octopart.com/34826-0124-Molex-datasheet-37169430.pdf?src-supplier=Electro+Sonic; ComponentLink7Description: http://datasheet.octopart.com/34826-0124-Molex-datasheet-41339625.pdf?src-supplier=Newark; ComponentLink7URL: http://datasheet.octopart.com/34826-0124-Molex-datasheet-41339625.pdf?src-supplier=Newark; ComponentLink8Description: http://datasheet.octopart.com/34826-0124-Molex-datasheet-41568714.pdf?src-supplier=Newark; ComponentLink8URL: http://datasheet.octopart.com/34826-0124-Molex-datasheet-41568714.pdf?src-supplier=Newark; ComponentLink9Description: http://datasheet.octopart.com/34826-0124-Molex-datasheet-56953327.pdf?src-supplier=Electro+Sonic; ComponentLink9URL: http://datasheet.octopart.com/34826-0124-Molex-datasheet-56953327.pdf?src-supplier=Electro+Sonic; ComponentLink10Description: http://datasheet.octopart.com/34826-0124-Molex-datasheet-62280374.pdf?src-supplier=Electro+Sonic; ComponentLink10URL: http://datasheet.octopart.com/34826-0124-Molex-datasheet-62280374.pdf?src-supplier=Electro+Sonic; ComponentLink11Description: http://datasheet.octopart.com/34826-0124-Molex-datasheet-66635110.pdf?src-supplier=Element14; ComponentLink11URL: http://datasheet.octopart.com/34826-0124-Molex-datasheet-66635110.pdf?src-supplier=Element14; Contact Material: Brass; Contact Plating: Nickel,Tin; Halogen Free: Low Halogen; Housing Material: Polyester; Library Name: WeOnReferenceBoard.SCHLIB; Manufacturer Part Number: 34826-0124; Max Operating Temperature: 105°C; Min Operating Temperature: -40°C; Mount: Surface Mount; Number of Contacts: 12; Number of Positions: 12; Number of Rows: 2; Orientation: Right Angle; Package Quantity: 520; Packaging: Tray; Pricing 1: 1 = 1.65000, 10 = 1.50100, 100 = 1.28650, 520 = 1.07206, 1040 = 0.91890, 5200 = 0.81476, 10400 = 0.79638 (USD); REACH SVHC: Unknown; Stock 1: 2751; Supplier 1: Digi-Key; Supplier Part Number 1: WM10328-ND; Termination: Solder
P5 P6 = FTSH105-05: GateName_1: G$1; Component Kind: Standard; DeviceSetName: FTSH105-05; Library Name: WeOnReferenceBoard.SCHLIB; LibraryName: WeOnReferencePlatform; SymbolName_1: FTSH105-05
Q1 = BUK9832-55A/CUX: BUK9832 Series 55 V 32 mOhm N-Channel TrenchMOS Logic Level FET - SOT-223; Manufacturer: Nexperia; Component Kind: Standard; ComponentLink1Description: http://datasheet.octopart.com/BUK9832-55A-CUX-Nexperia-datasheet-87843131.pdf?src-supplier=Future+Electronics; ComponentLink1URL: http://datasheet.octopart.com/BUK9832-55A-CUX-Nexperia-datasheet-87843131.pdf?src-supplier=Future+Electronics; ComponentLink2Description: http://datasheet.octopart.com/BUK9832-55A-CUX-NXP-Semiconductors-datasheet-10127705.pdf?src-supplier=NXP+Semiconductors; ComponentLink2URL: http://datasheet.octopart.com/BUK9832-55A-CUX-NXP-Semiconductors-datasheet-10127705.pdf?src-supplier=NXP+Semiconductors; Manufacturer Part Number: BUK9832-55A/CUX; Pricing 1: 1 = 0.79000, 10 = 0.69400, 100 = 0.53540, 500 = 0.39660 (USD); Stock 1: 84864; Supplier 1: Digi-Key; Supplier Part Number 1: 1727-2226-1-ND
Q2 Q3 = BSS138BKW,115: BSS138BKW - 60 V, 320 mA N-channel Trench MOSFET; Manufacturer: Nexperia; Component Kind: Standard; ComponentLink1Description: http://datasheet.octopart.com/BSS138BKW%2C115-Nexperia-datasheet-86648463.pdf?src-supplier=Future+Electronics; ComponentLink1URL: http://datasheet.octopart.com/BSS138BKW%2C115-Nexperia-datasheet-86648463.pdf?src-supplier=Future+Electronics; ComponentLink2Description: http://datasheet.octopart.com/BSS138BKW%2C115-Nexperia-datasheet-10411339.pdf?src-supplier=NXP+Semiconductors; ComponentLink2URL: http://datasheet.octopart.com/BSS138BKW%2C115-Nexperia-datasheet-10411339.pdf?src-supplier=NXP+Semiconductors; ComponentLink3Description: http://datasheet.octopart.com/BSS138BKW%2C115-NXP-Semiconductors-datasheet-11836202.pdf?src-supplier=Arrow; ComponentLink3URL: http://datasheet.octopart.com/BSS138BKW%2C115-NXP-Semiconductors-datasheet-11836202.pdf?src-supplier=Arrow; Library Name: WeOnReferenceBoard.SCHLIB; Manufacturer Part Number: BSS138BKW,115; Pricing 1: 3000 = 0.06755 (USD); Pricing 2: 1 = 0.36000, 10 = 0.24100, 50 = 0.24100, 100 = 0.10100, 1000 = 0.06900, 10000 = 0.04600 (USD); Stock 1: 57000; Stock 2: 57224; Supplier 1: Digi-Key; Supplier 2: Mouser; Supplier Part Number 1: 1727-1262-2-ND; Supplier Part Number 2: 771-BSS138BKW115
R1 R4 = ERJ-2GEJ150X: Res Thick Film 0402 15 Ohm 5% 1/10W ±200ppm/°C Molded SMD SMD Punched Carrier T/R; Manufacturer: Panasonic; Max Power Dissipation: 100mW; Voltage Rating: 50V; Case/Package: 0402; Case Code (Imperial): 0402; Case Code (Metric): 1005; Component Kind: Standard; ComponentLink1Description: https://www.alliedelec.com/m/d/51cd0790071e1666bdb9d00c57fcf960.pdf?src-supplier=Allied+Electronics; ComponentLink1URL: https://www.alliedelec.com/m/d/51cd0790071e1666bdb9d00c57fcf960.pdf?src-supplier=Allied+Electronics; ComponentLink2Description: http://www.industrial.panasonic.com/www-data/pdf/AOA0000/AOA0000CE1.pdf?src-supplier=Element14; ComponentLink2URL: http://www.industrial.panasonic.com/www-data/pdf/AOA0000/AOA0000CE1.pdf?src-supplier=Element14; ComponentLink3Description: http://www.farnell.com/datasheets/2059623.pdf?src-supplier=Farnell; ComponentLink3URL: http://www.farnell.com/datasheets/2059623.pdf?src-supplier=Farnell; ComponentLink4Description: http://industrial.panasonic.com/www-data/pdf/AOA0000/AOA0000CE1.pdf?src-supplier=Avnet; ComponentLink4URL: http://industrial.panasonic.com/www-data/pdf/AOA0000/AOA0000CE1.pdf?src-supplier=Avnet; ComponentLink5Description: http://www.farnell.com/datasheets/1678796.pdf?src-supplier=Element14; ComponentLink5URL: http://www.farnell.com/datasheets/1678796.pdf?src-supplier=Element14; ComponentLink6Description: http://datasheet.octopart.com/ERJ-2GEJ150X-Panasonic-datasheet-61114972.pdf?src-supplier=Farnell; ComponentLink6URL: http://datasheet.octopart.com/ERJ-2GEJ150X-Panasonic-datasheet-61114972.pdf?src-supplier=Farnell; ComponentLink7Description: http://datasheet.octopart.com/ERJ-2GEJ150X-Panasonic-datasheet-13266872.pdf?src-supplier=Allied+Electronics; ComponentLink7URL: http://datasheet.octopart.com/ERJ-2GEJ150X-Panasonic-datasheet-13266872.pdf?src-supplier=Allied+Electronics; ComponentLink8Description: http://datasheet.octopart.com/ERJ-2GEJ150X-Panasonic-datasheet-5314550.pdf?src-supplier=Farnell; ComponentLink8URL: http://datasheet.octopart.com/ERJ-2GEJ150X-Panasonic-datasheet-5314550.pdf?src-supplier=Farnell; ComponentLink9Description: http://datasheet.octopart.com/ERJ-2GEJ150X-Panasonic-datasheet-33006990.pdf?src-supplier=Newark; ComponentLink9URL: http://datasheet.octopart.com/ERJ-2GEJ150X-Panasonic-datasheet-33006990.pdf?src-supplier=Newark; ComponentLink10Description: http://datasheet.octopart.com/ERJ-2GEJ150X-Panasonic-datasheet-37173568.pdf?src-supplier=Electro+Sonic; ComponentLink10URL: http://datasheet.octopart.com/ERJ-2GEJ150X-Panasonic-datasheet-37173568.pdf?src-supplier=Electro+Sonic; ComponentLink11Description: http://datasheet.octopart.com/ERJ-2GEJ150X-Panasonic-datasheet-78375410.pdf; ComponentLink11URL: http://datasheet.octopart.com/ERJ-2GEJ150X-Panasonic-datasheet-78375410.pdf; ComponentLink12Description: http://datasheet.octopart.com/ERJ-2GEJ150X-Panasonic-datasheet-118598.pdf?src-supplier=Digi-Key; ComponentLink12URL: http://datasheet.octopart.com/ERJ-2GEJ150X-Panasonic-datasheet-118598.pdf?src-supplier=Digi-Key; ComponentLink13Description: http://datasheet.octopart.com/ERJ-2GEJ150X-Panasonic---ECG-datasheet-15994758.pdf?src-supplier=Farnell; ComponentLink13URL: http://datasheet.octopart.com/ERJ-2GEJ150X-Panasonic---ECG-datasheet-15994758.pdf?src-supplier=Farnell; ComponentLink14Description: http://datasheet.octopart.com/ERJ-2GEJ150X-Panasonic---ECG-datasheet-21211697.pdf?src-supplier=Newark; ComponentLink14URL: http://datasheet.octopart.com/ERJ-2GEJ150X-Panasonic---ECG-datasheet-21211697.pdf?src-supplier=Newark; ComponentLink15Description: http://datasheet.octopart.com/ERJ2GEJ150X-Panasonic-datasheet-27908757.pdf?src-supplier=Electro+Sonic; ComponentLink15URL: http://datasheet.octopart.com/ERJ2GEJ150X-Panasonic-datasheet-27908757.pdf?src-supplier=Electro+Sonic; Composition: Thick Film; Contact Plating: Tin; Depth: 0.5mm; Features: Automotive AEC-Q200; Height: 0.35mm; Lead Free: Lead Free; Length: 1mm; Manufacturer Part Number: ERJ-2GEJ150X; Max Operating Temperature: 155°C; Military Standard: Not; Min Operating Temperature: -55°C; Mount: Surface Mount; Number of Terminations: 2; Package Quantity: 1; Packaging: Tape and Reel; Power Rating: 100mW; Pricing 1: 1 = 0.00250, 100 = 0.00230, 6000 = 0.00220 (USD); Radiation Hardening: No; REACH SVHC: No SVHC; Stock 1: 16044; Supplier 1: Arrow; Supplier Part Number 1: ERJ-2GEJ150X; Temperature Coefficient: 200ppm/°C; Termination: SMD/SMT; Tolerance: 5%; Voltage Rating (DC): 50V; Width: 0.5mm
R2 = RK73B1ETTP511J: Res Thick Film 0402 510 Ohm 5% 0.1W(1/10W) ±200ppm/C Pad SMD Automotive T/R; Manufacturer: KOA Speer; Power Rating: 63mW; Temperature Coefficient: 200ppm/°C; Voltage Rating: 50V; Case/Package: 0402; Case Code (Imperial): 0402; Case Code (Metric): 1005; Component Kind: Standard; ComponentLink1Description: https://www.verical.com/server-webapp/html/getDatasheet?partID=163952&src-supplier=Verical; ComponentLink1URL: https://www.verical.com/server-webapp/html/getDatasheet?partID=163952&src-supplier=Verical; ComponentLink2Description: http://datasheet.octopart.com/RK73B1ETTP511J-KOA-Speer-datasheet-11541631.pdf; ComponentLink2URL: http://datasheet.octopart.com/RK73B1ETTP511J-KOA-Speer-datasheet-11541631.pdf; ComponentLink3Description: http://datasheet.octopart.com/RK73B1ETTP511J-KOA-Speer-datasheet-109291.pdf?src-supplier=Garrett; ComponentLink3URL: http://datasheet.octopart.com/RK73B1ETTP511J-KOA-Speer-datasheet-109291.pdf?src-supplier=Garrett; ComponentLink4Description: http://datasheet.octopart.com/RK73B1ETTP511J-KOA-Speer-datasheet-5377395.pdf?src-supplier=Garrett; ComponentLink4URL: http://datasheet.octopart.com/RK73B1ETTP511J-KOA-Speer-datasheet-5377395.pdf?src-supplier=Garrett; ComponentLink5Description: http://datasheet.octopart.com/RK73B1ETTP511J-KOA-Speer-datasheet-5377795.pdf?src-supplier=Garrett; ComponentLink5URL: http://datasheet.octopart.com/RK73B1ETTP511J-KOA-Speer-datasheet-5377795.pdf?src-supplier=Garrett; ComponentLink6Description: http://datasheet.octopart.com/RK73B1ETTP511J-KOA-Speer-datasheet-11616998.pdf?src-supplier=Garrett; ComponentLink6URL: http://datasheet.octopart.com/RK73B1ETTP511J-KOA-Speer-datasheet-11616998.pdf?src-supplier=Garrett; ComponentLink7Description: http://datasheet.octopart.com/RK73B-%7C-General-Purpose-2%25%2C-5%25-Tolerance-Thick-Film-Chip-Resistor-KOA-Speer-datasheet-family-86.pdf; ComponentLink7URL: http://datasheet.octopart.com/RK73B-%7C-General-Purpose-2%25%2C-5%25-Tolerance-Thick-Film-Chip-Resistor-KOA-Speer-datasheet-family-86.pdf; Composition: Thick Film; Depth: 0.5mm; Height: 0.35mm; Lead Free: Lead Free; Length: 1mm; Manufacturer Part Number: RK73B1ETTP511J; Max Operating Temperature: 155°C; Military Standard: MIL-R-55342F; Min Operating Temperature: -55°C; Mount: Surface Mount; Package Quantity: 10000; Packaging: Tape and Reel; Pricing 1: 1 = 0.00090, 500 = 0.00080 (USD); Stock 1: 9725; Supplier 1: Arrow; Termination: SMD/SMT; Tolerance: 5%; Voltage Rating (DC): 50V; Width: 0.5mm
R3 = ERJ-2GEJ512X: Res Thick Film 0402 5.1K Ohm 5% 1/10W ±200ppm/°C Molded SMD SMD Punched Carrier T/R; Manufacturer: Panasonic; Max Power Dissipation: 100mW; Voltage Rating: 50V; Case/Package: 0402; Case Code (Imperial): 0402; Case Code (Metric): 1005; Component Kind: Standard; ComponentLink1Description: http://industrial.panasonic.com/www-data/pdf/AOA0000/AOA0000CE1.pdf?src-supplier=Avnet; ComponentLink1URL: http://industrial.panasonic.com/www-data/pdf/AOA0000/AOA0000CE1.pdf?src-supplier=Avnet; ComponentLink2Description: https://www.alliedelec.com/m/d/51cd0790071e1666bdb9d00c57fcf960.pdf?src-supplier=Allied+Electronics; ComponentLink2URL: https://www.alliedelec.com/m/d/51cd0790071e1666bdb9d00c57fcf960.pdf?src-supplier=Allied+Electronics; ComponentLink3Description: http://www.farnell.com/datasheets/1500035.pdf?src-supplier=Element14; ComponentLink3URL: http://www.farnell.com/datasheets/1500035.pdf?src-supplier=Element14; ComponentLink4Description: http://datasheet.octopart.com/ERJ-2GEJ512X-Panasonic-datasheet-13266872.pdf?src-supplier=Newark; ComponentLink4URL: http://datasheet.octopart.com/ERJ-2GEJ512X-Panasonic-datasheet-13266872.pdf?src-supplier=Newark; ComponentLink5Description: http://datasheet.octopart.com/ERJ-2GEJ512X-Panasonic-datasheet-61114972.pdf?src-supplier=Farnell; ComponentLink5URL: http://datasheet.octopart.com/ERJ-2GEJ512X-Panasonic-datasheet-61114972.pdf?src-supplier=Farnell; ComponentLink6Description: http://datasheet.octopart.com/ERJ-2GEJ512X-Panasonic-datasheet-5314550.pdf?src-supplier=Element14; ComponentLink6URL: http://datasheet.octopart.com/ERJ-2GEJ512X-Panasonic-datasheet-5314550.pdf?src-supplier=Element14; ComponentLink7Description: http://datasheet.octopart.com/ERJ-2GEJ512X-Panasonic-datasheet-37173568.pdf?src-supplier=Electro+Sonic; ComponentLink7URL: http://datasheet.octopart.com/ERJ-2GEJ512X-Panasonic-datasheet-37173568.pdf?src-supplier=Electro+Sonic; ComponentLink8Description: http://datasheet.octopart.com/ERJ-2GEJ512X-Panasonic-datasheet-78375410.pdf; ComponentLink8URL: http://datasheet.octopart.com/ERJ-2GEJ512X-Panasonic-datasheet-78375410.pdf; ComponentLink9Description: http://datasheet.octopart.com/ERJ-2GEJ512X-Panasonic-datasheet-118598.pdf?src-supplier=Digi-Key; ComponentLink9URL: http://datasheet.octopart.com/ERJ-2GEJ512X-Panasonic-datasheet-118598.pdf?src-supplier=Digi-Key; ComponentLink10Description: http://datasheet.octopart.com/ERJ-2GEJ512X-Panasonic-datasheet-5313456.pdf?src-supplier=Farnell; ComponentLink10URL: http://datasheet.octopart.com/ERJ-2GEJ512X-Panasonic-datasheet-5313456.pdf?src-supplier=Farnell; ComponentLink11Description: http://datasheet.octopart.com/ERJ-2GEJ512X-Panasonic-datasheet-10554283.pdf?src-supplier=Farnell; ComponentLink11URL: http://datasheet.octopart.com/ERJ-2GEJ512X-Panasonic-datasheet-10554283.pdf?src-supplier=Farnell; ComponentLink12Description: http://datasheet.octopart.com/ERJ-2GEJ512X-Panasonic---ECG-datasheet-15994758.pdf?src-supplier=Farnell; ComponentLink12URL: http://datasheet.octopart.com/ERJ-2GEJ512X-Panasonic---ECG-datasheet-15994758.pdf?src-supplier=Farnell; ComponentLink13Description: http://datasheet.octopart.com/ERJ2GEJ512X-Panasonic-datasheet-27908757.pdf?src-supplier=Electro+Sonic; ComponentLink13URL: http://datasheet.octopart.com/ERJ2GEJ512X-Panasonic-datasheet-27908757.pdf?src-supplier=Electro+Sonic; Composition: Thick Film; Contact Plating: Tin; Depth: 0.5mm; Height: 0.35mm; Lead Free: Lead Free; Length: 1mm; Manufacturer Part Number: ERJ-2GEJ512X; Max Operating Temperature: 155°C; Military Standard: Not; Min Operating Temperature: -55°C; Mount: Surface Mount; Number of Terminations: 2; Package Quantity: 1; Packaging: Tape and Reel; Power Rating: 100mW; Pricing 1: 1 = 0.10000, 10 = 0.03000, 100 = 0.01230, 1000 = 0.00551, 2500 = 0.00478, 5000 = 0.00395 (USD); Radiation Hardening: No; REACH SVHC: No SVHC; Stock 1: 1622396; Supplier 1: Digi-Key; Supplier Part Number 1: P5.1KJCT-ND; Temperature Coefficient: 200ppm/°C; Termination: SMD/SMT; Tolerance: 5%; Voltage Rating (DC): 50V; Width: 0.02inch
